# Altium schematic sheet mpustm.SchDoc(mpustm)
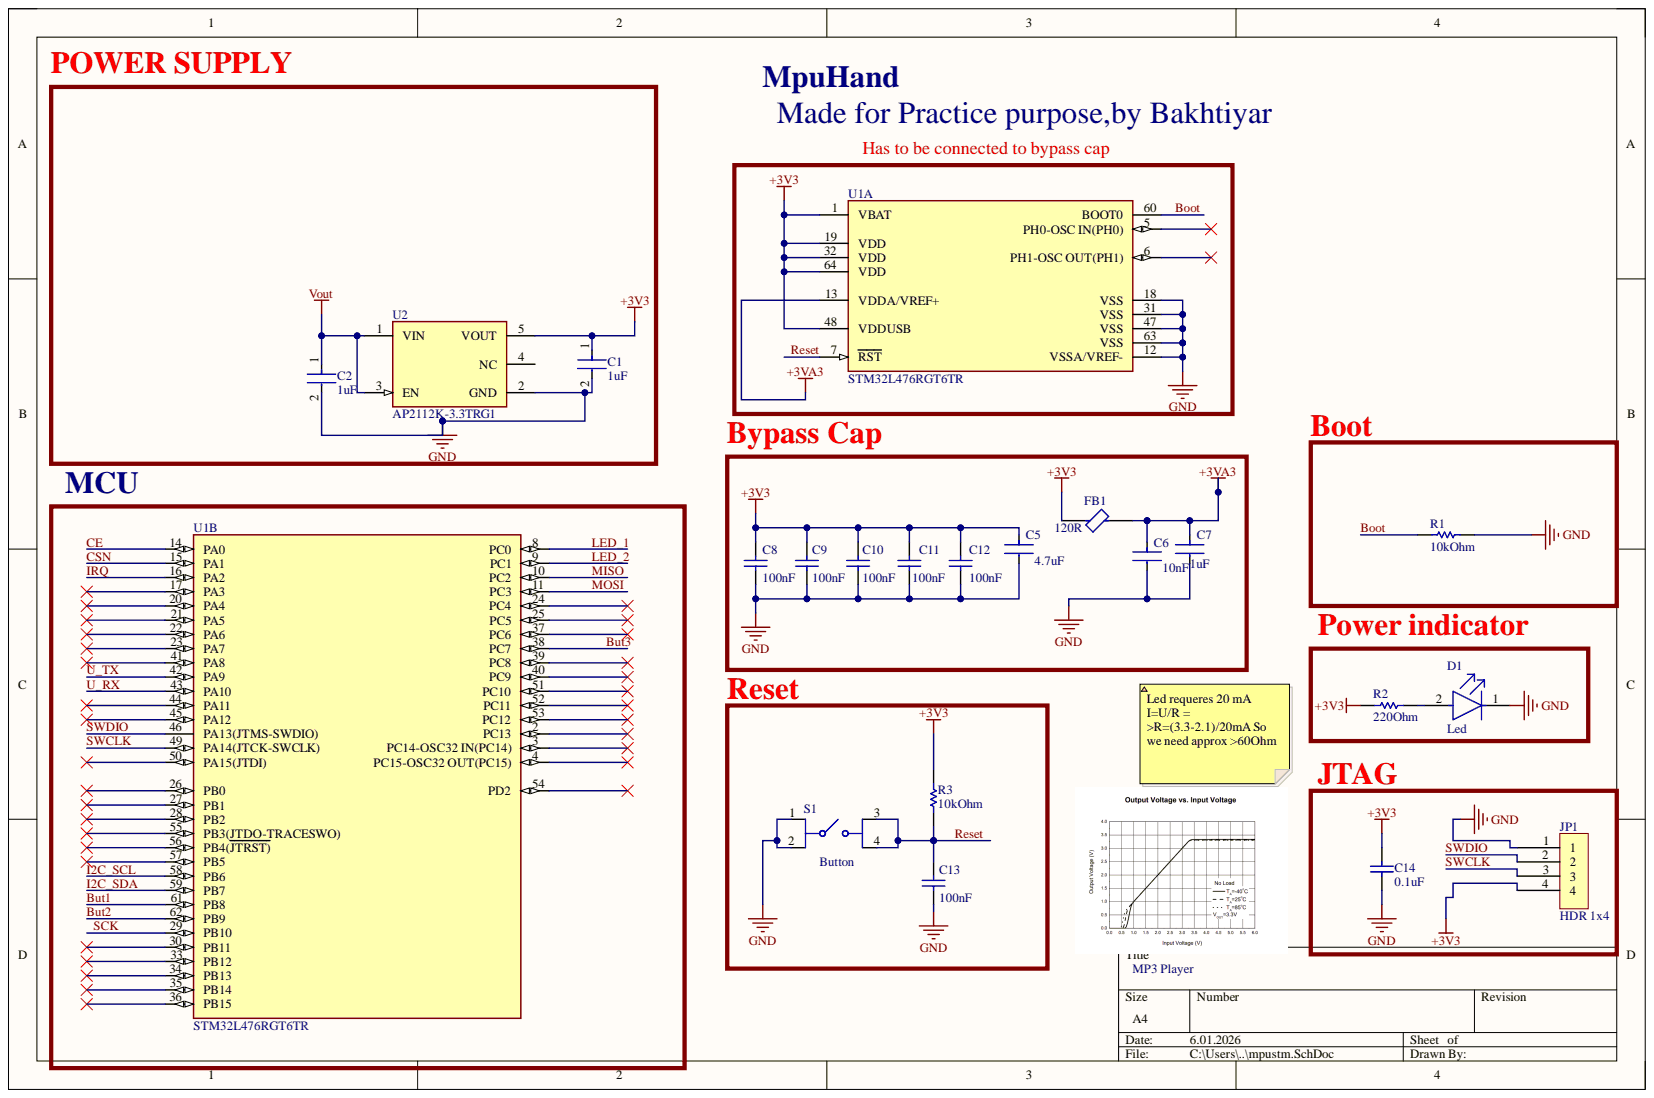
[diagram p0: mpustm.SchDoc]

## Parts
C1  1uF  [FP-CC0805-0_85-MFG]
C2  1uF  [FP-CC0805-0_85-MFG]
C5  4.7uF  [FP-CL282-IPC_C]
C6  10nF  [FP-CL165-IPC_C]
C7  1uF  [FP-CL511-IPC_C]
C8  100nF  [CAPC1050X55X23ML05T13]
C9  100nF  [CAPC1050X55X23ML05T13]
C10  100nF  [CAPC1050X55X23ML05T13]
C11  100nF  [CAPC1050X55X23ML05T13]
C12  100nF  [CAPC1050X55X23ML05T13]
C13  100nF  [CAPC1050X55X23ML05T13]
C14  0.1uF  [CAPC1050X55X23ML05T13]
D1  Led  [FP-LTST-C191GKT-MFG]
FB1  120R  [FP-BLM18-0_15-t0_8-IPC_C]
JP1  HDR 1x4  [HDR Male1_4 2.54mm]
R1  10kOhm  [RESC2013X60X35ML10T20]
R2  220Ohm  [RESC1608X55X25ML10T15]
R3  10kOhm  [RESC2013X60X35ML10T20]
S1  Button  [GSPTS004901]
U1  STM32L476RGT6TR  [FP-LQFP64-5W-MFG]  (parts A,B)
U2  AP2112K-3.3TRG1  [FP-SOT25-IPC_A]

## Nets
+3V3: C1.1 C5.1 C8.2 C9.2 C10.2 C11.2 C12.2 C14.2 FB1.1 JP1.4 R2.2 R3.2 U1.1(VBAT) U1.19(VDD) U1.32(VDD) U1.48(VDDUSB) U1.64(VDD) U2.5(VOUT)
+3VA3: C6.1 C7.1 FB1.2 U1.13(VDDA/VREF+)
GND: C1.2 C2.2 C5.2 C6.2 C7.2 C8.1 C9.1 C10.1 C11.1 C12.1 C13.1 C14.1 D1.1 JP1.1 R1.1 S1.1 S1.2 U1.12(VSSA/VREF-) U1.18(VSS) U1.31(VSS) U1.47(VSS) U1.63(VSS) U2.2(GND)
Vout: C2.1 U2.1(VIN) U2.3(EN)
Boot: R1.2 U1.60(BOOT0)
But1: U1.61(PB8)
But2: U1.62(PB9)
But3: U1.38(PC7)
CE: U1.14(PA0)
CSN: U1.15(PA1)
I2C_SCL: U1.58(PB6)
I2C_SDA: U1.59(PB7)
IRQ: U1.16(PA2)
LED_1: U1.8(PC0)
LED_2: U1.9(PC1)
MISO: U1.10(PC2)
MOSI: U1.11(PC3)
Reset: C13.2 R3.1 S1.3 S1.4 U1.7(RST)
SCK: U1.29(PB10)
SWCLK: JP1.3 U1.49(PA14(JTCK-SWCLK))
SWDIO: JP1.2 U1.46(PA13(JTMS-SWDIO))
U_RX: U1.43(PA10)
U_TX: U1.42(PA9)
NetD1_2: D1.2 R2.1

Not connected: U1 2(PC13) 3(PC14-OSC32_IN(PC14)) 4(PC15-OSC32_OUT(PC15)) 5(PH0-OSC_IN(PH0)) 6(PH1-OSC_OUT(PH1)) 17(PA3) 20(PA4) 21(PA5) 22(PA6) 23(PA7) 24(PC4) 25(PC5) 26(PB0) 27(PB1) 28(PB2) 30(PB11) 33(PB12) 34(PB13) 35(PB14) 36(PB15) 37(PC6) 39(PC8) 40(PC9) 41(PA8) 44(PA11) 45(PA12) 50(PA15(JTDI)) 51(PC10) 52(PC11) 53(PC12) 54(PD2) 55(PB3(JTDO-TRACESWO)) 56(PB4(JTRST)) 57(PB5); U2 4(NC)

## Library data of the parts
C1 C2 = CMP-03422-000864-1: CAP CER 1UF 50V X7R 0805; Manufacturer: Yageo; Manufacturer Part Number: CC0805KKX7R9BB105; Material: Ceramic; Tolerance: 0.1; Automotive: No; Capacitance: 1 uF; Category: Cap; Component Kind: Standard; ComponentLink2Description: Datasheet; ComponentLink2URL: http://www.yageo.com/documents/recent/UPY-GPHC_Y5V_6.3V-to-50V_8.pdf; Device Class L1: Passive Components; Device Class L2: Capacitors; Device Class L3: Ceramic Capacitors; Digikey Description: CAP CER 1UF 50V X7R 0805; Footprint Url: http://www.yageo.com/exep/pages/download/literatures/UPY-C_GEN_24.pdf; Height: 0.95mm; Ipc Land Pattern Name: CAPC200125X85; Lead Free: Yes; Library Name: Altium Content Vault; Mouser Description: Multilayer Ceramic Capacitors MLCC - SMD/SMT 1.0uF 50V X7R 10%; Octopart: https://octopart.com/cc0805kkx7r9bb105-yageo-21707071; Package: CC0805-0.85; RoHS: TRUE; Temperature: -30°C to +85°C; Temperature Characteristic: X7R; Temperature Range High: +85°C; Temperature Range Low: -30°C; Value: 1 uF; Voltage Rating: 50 V
C5 = CMP-13271-000548-1: Cap Ceramic 4.7uF 10V X5R ±20% SMD 0402 +85°C Paper T/R; Capacitance: 4.7uF; Case Code (Imperial): 0402; Case Code (Metric): 1005; Case/Package: 0402; Component Kind: Standard; Dielectric: X5R; Lead Free: Lead Free; Length: 0.039inch; Library Name: Altium Content Vault; Max Operating Temperature: 85°C; Min Operating Temperature: -55°C; Mount: Surface Mount; Package Quantity: 10000; Packaging: Tape and Reel; Thickness: 0.022inch; Tolerance: 20%; Voltage Rating: 10V; Width: 0.02inch
C6 = CMP-13271-000387-1: Cap Ceramic 10nF 50V X7R ±10% SMD 0402 +125°C Paper T/R; Capacitance: 10nF; Case Code (Imperial): 0402; Case Code (Metric): 1005; Case/Package: 0402; Component Kind: Standard; Contact Plating: Tin; Depth: 0.5mm; Dielectric: X7R; Height: 0.5mm; Lead Free: Lead Free; Length: 1mm; Library Name: Altium Content Vault; Material: Ceramic; Max Operating Temperature: 125°C; Min Operating Temperature: -55°C; Mount: Surface Mount; Package Quantity: 1; Packaging: Tape and Reel; Radiation Hardening: No; Thickness: 0.022inch; Tolerance: 10%; Voltage: 50V; Voltage Rating: 50V; Voltage Rating (DC): 50V; Width: 0.02inch
C7 = CMP-13271-001936-1: Cap Ceramic 1uF 10V X5R ±10% SMD 0402 +85°C Paper T/R; Capacitance: 1uF; Case Code (Imperial): 0402; Case Code (Metric): 1005; Case/Package: 0402; Component Kind: Standard; Contact Plating: Tin; Depth: 0.5mm; Dielectric: X5R; Height: 0.5mm; Length: 1mm; Library Name: Altium Content Vault; Material: Ceramic; Max Operating Temperature: 85°C; Min Operating Temperature: -55°C; Mount: Surface Mount; Package Quantity: 1; Packaging: Tape and Reel; Radiation Hardening: No; Thickness: 0.022inch; Tolerance: 10%; Voltage Rating: 10V; Width: 0.02inch
C8-C14 = CMP-2000-05439-1: Chip Capacitor, 100 nF, +/- 10%, 16 V, -55 to 125 degC, 0402 (1005 Metric), RoHS, Tape and Reel; Manufacturer: Samsung Electro-Mechanics; Capacitance: 100000pF; Dielectric: X7R; Rated Voltage: 16V; Case Size/Dimension: 0402; Component Kind: Standard; Datasheet URL: https://datasheet.ciiva.com/29198/458718-da-01-en-vs-kondensator-0402-29198423.pdf; Datasheet Version: Nov-10; Library Name: Altium Content Vault; Manufacturer URL: http://www.samsungsem.com/global/index.jsp; Tolerance (%): ±10%
D1 = CMP-22017-000029-1: LED GREEN CLEAR CHIP SMD; Dominant Wavelength: 569nm; Forward Current: 30mA; Forward Voltage: 2.1V; Lens Color: Colorless; Luminous Intensity: 12mcd; Number of Elements: 1; Power Dissipation: 100mW; Reverse Voltage: 5V; Test Current: 20mA; Viewing Angle: 130°; Wavelength: 565nm; Case Code (Imperial): 0603; Case Code (Metric): 1608; Case/Package: 0603; Color: Green; Component Kind: Standard; Depth: 0.8mm; Height: 0.55mm; Illumination Color: Green; Lead Free: Lead Free; Length: 1.6mm; Lens Style: Clear; Lens Transparency: Clear; Library Name: Altium Content Vault; Max Operating Temperature: 85°C; Min Operating Temperature: -55°C; Mount: Surface Mount; Number of LEDs: 1; Number of Pins: 2; Package Quantity: 1; Packaging: Tape and Reel; Power Rating: 100mW; Radiation Hardening: No; Width: 0.8mm
FB1 = CMP-0686-00425-3: Chip Ferrite Bead, 0603, 5Ω @ 100MHz, 0.05Ω, 25%, 800mA; Current Rating: 700mA; DC Resistance (DCR): 50mR; Impedance: 5R; Number of Circuits: 1; Shielding: Unshielded; Case Code (Imperial): 0603; Case Code (Metric): 1608; Case/Package: 0603; Component Kind: Standard; Core Material: Ferrite; DC Current: 700mA; Depth: 0.8mm; Height: 0.8mm; Lead Free: Lead Free; Length: 1.6mm; Library Name: Altium Content Vault; Material: Ferrite; Max Current Rating: 700mA; Max DC Current: 700mA; Max Operating Temperature: 125°C; Military Standard: Not; Min Operating Temperature: -55°C; Number of Lines: 1; Number of Pins: 2; Package Quantity: 1; Packaging: Tape and Reel; Radiation Hardening: No; REACH SVHC: No SVHC; Termination: SMD/SMT; Test Frequency: 100MHz; Tolerance: 25%; Width: 0.8mm
JP1 = 68001-204HLF: CONN HEADER VERT 4POS 2.54MM; Manufacturer: Amphenol FCI; Connector Type: Male Pin; Contact Post Length: 3.05mm; Current Rating: 3A; Gender: Male; Insulation Resistance: 5GR; Number of Contacts: 4; Voltage Rating: 1.5kV; Category: Headers and Wire Housings; Color: Black; Component Kind: Standard; ComponentLink1Description: https://datasheet.ciiva.com/5164/68000-274255-5164127.pdf?src-supplier=Mouser; ComponentLink1URL: https://datasheet.ciiva.com/5164/68000-274255-5164127.pdf?src-supplier=Mouser; ComponentLink2Description: https://datasheet.ciiva.com/726/68000-726529.pdf?src-supplier=Digi-Key; ComponentLink2URL: https://datasheet.ciiva.com/726/68000-726529.pdf?src-supplier=Digi-Key; Contact Gender: Male; Contact Material: Bronze; Contact Mating Length: 0.23inch; Contact Plating: Gold,GXT; Flammability Rating: UL94 V-0; Housing Material: Thermoplastic; Library Name: Male_Header_1_4 2.54mm.Schlib; Manufacturer Part Number: 68001-204HLF; Max Operating Temperature: 125°C; Min Operating Temperature: -65°C; Mount: Through Hole; Number of Positions: 4; Number of Rows: 1; Orientation: Straight; Package Quantity: 100; Packaging: Bulk; Pitch: 2.54mm; Pricing 1: 1=0,38000, 10=0,35700, 100=0,25010, 500=0,21442, 1000=0,17868, 5000=0,15315, 10000=0,14294 (USD); Radiation Hardening: No; RoHS: Yes; Stock 1: 2857; Supplier 1: Digi-Key; Supplier Part Number 1: 609-3402-ND; Termination: Solder
R1 R3 = CMP-2000-05025-1: Chip Resistor, 10 KOhm, +/- 5%, 0.125 W, -55 to 155 degC, 0805 (2012 Metric), RoHS, Tape and Reel; Manufacturer: Yageo; Power Rating: 250mW; Product Range: RCSeries; Resistor Case Style: 0805[2012Metric]; Resistor Element Type: ThickFilm; SVHC: ToBeAdvised; Temperature Coefficient: ±100ppm/degC; Voltage Rating: 150V; Automotive Qualification Standard: -; Component Kind: Standard; Datasheet URL: http://www.yageo.ru/pdf/RC0805.pdf; Datasheet Version: REV. 2, 09/2004; Library Name: Altium Content Vault; Manufacturer URL: http://www.yageo.com/; MSL: MSL1-Unlimited; Package Description: 2-Pin Surface Mounted Device, Body 2 x 1.25 mm; Package Reference: 805; Packaging: CutTape; Resistance: 10kohm; Resistance Tolerance: ±5%
R2 = CMP-1659-00018-2: General Purpose Chip Resistors, 220 Ohm, +/- 1%, -55 to 155 degC, 0603 (1608 Metric), RoHS, Tape and Reel; Component Kind: Standard; ComponentLink1Description: Manufacturer URL; ComponentLink1URL: http://www.yageo.com/; ComponentLink2Description: Datasheet; ComponentLink2URL: https://datasheet.ciiva.com/11808/0900766b80f96399-11808136.pdf; DatasheetVersion: Rev. 4, 04/2009; Library Name: Altium Content Vault; PackageDescription: 2-Pin Surface Mount Device, Body 1.6 x 0.8 mm; PackageReference: 0603; RoHSCompliant: false
S1 = GSPTS004901: Switch (4-Pin Push); Manufacturer_Name: C & K COMPONENTS; Component Kind: Standard; Datasheet Link: https://www.ckswitches.com/media/2780/pts526.pdf; Height: 1.65mm; Library Name: SamacSys.SchLib; Manufacturer_Part_Number: GSPTS004901
U1 = CMP-12105-000039-1: IC MCU 32BIT 1MB FLASH 64LQFP; Manufacturer: STMicroelectronics; Core Architecture: ARM Cortex-M4; Data Bus Width: 32bits; Frequency: 80MHz; Interface: I2C,SPI,UART,USART,CAN,USB,LIN,IrDA,SMBus,Other; Max Junction Temp: +105°C; Max Supply Voltage: 3.6V; Memory Size: 1MBytes; Min Supply Voltage: 1.71V; Number Of A/D Converters: 3; Number Of Adc Channels: 16; Number Of Cores: 1; Number Of I/Os: 51; Number Of Pwm Channels: 28; Number Of Timers/Counters: 13; Number Of Uart Channels: 6; Ram Size: 128KBytes; Standoff Height: 0.05mm; Ambient Temperature Range High: +85°C; Ambient Temperature Range Low: -40°C; Automotive: No; Category: IC; Component Kind: Standard; ComponentLink2Description: Datasheet; ComponentLink2URL: https://www.st.com/content/ccc/resource/technical/document/datasheet/c5/ed/2f/60/aa/79/42/0b/DM00108832.pdf/files/DM00108832.pdf/jcr:content/translations/en.DM00108832.pdf; Device Class L1: Integrated Circuits (ICs); Device Class L2: Embedded Processors and Controllers; Device Class L3: Microcontrollers; Digikey Description: IC MCU 32BIT 1MB FLASH 64LQFP; Height: 1.6mm; Ipc Land Pattern Name: QFP50P1200X1200X160-64; Lead Free: Yes; Library Name: Altium Content Vault; Manufacturer Part Number: STM32L476RGT6TR; Memory Type: FLASH; Mouser Description: MCU 32-Bit STM32 ARM Cortex M4 RISC 1MB Flash 1.8V/2.5V/3.3V 64-Pin LQFP T/R; Number Of I2C Channels: 3; Number Of Spi Channels: 3; Number Of Usb Channels: 1; Octopart: https://octopart.com/stm32l476rgt6tr-stmicroelectronics-74146120; Package: LQFP64-5W; RoHS: TRUE; Temperature: -40°C to +105°C; Temperature Range High: +105°C; Temperature Range Low: -40°C
U2 = CMP-12756-000035-1: IC REG LINEAR 3.3V 600MA SOT25; Accuracy: 1.5%; Dropout Voltage: 250mV; Max Input Voltage: 6V; Min Input Voltage: 2.5V; Number of Outputs: 1; Output Type: Fixed; Polarity: Positive; Quiescent Current: 55uA; Case/Package: SOT-23-5; Component Kind: Standard; Height: 1.3mm; Lead Free: Lead Free; Length: 3.1mm; Library Name: Altium Content Vault; Max Operating Temperature: 85°C; Max Output Current: 600mA; Min Operating Temperature: -40°C; Mount: Surface Mount; Number of Pins: 5; Number of Regulators: 1; Output Current: 600mA; Output Voltage: 3.3V; Package Quantity: 1; Packaging: Tape and Reel; Width: 1.7mm
